# Altium schematic sheet Regulator.SchDoc
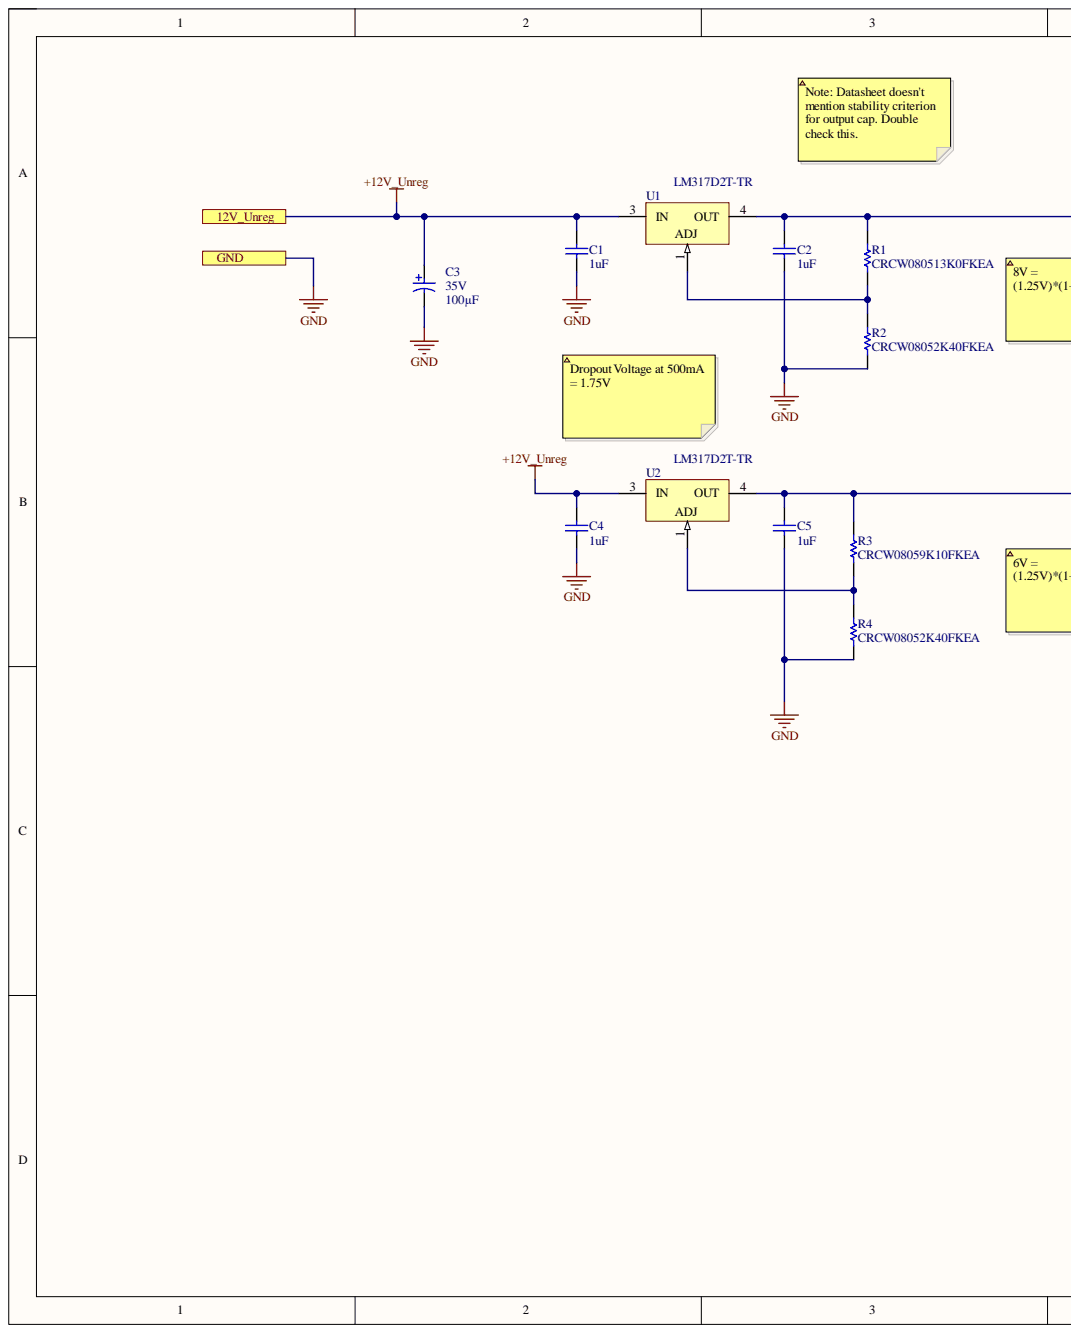
[diagram p0: Regulator.SchDoc, left]
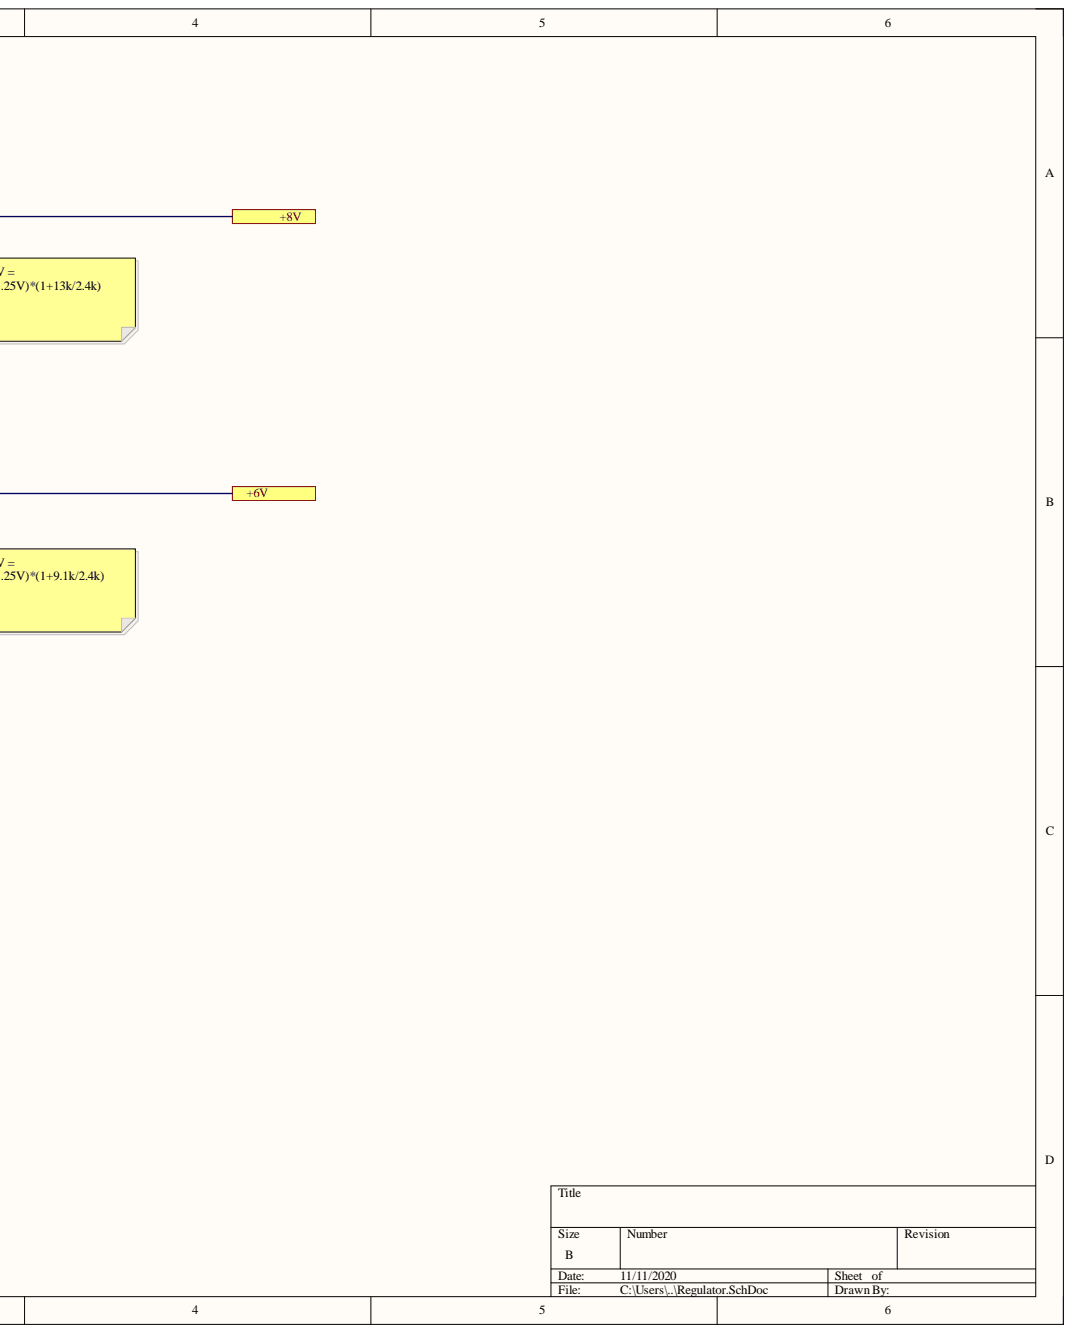
[diagram p1: Regulator.SchDoc, right]

## Parts
C1  1uF  [CAPC2013X100X50NL20T25]
C2  1uF  [CAPC2013X100X50NL20T25]
C3  Capacitance: 100µF  Voltage Rating: 35V  [PNSC-FC-V-G_V]  (hidden comment: EEEFC1V101P)
C4  1uF  [CAPC2013X100X50NL20T25]
C5  1uF  [CAPC2013X100X50NL20T25]
R1  CRCW080513K0FKEA  [RESC2013X50X30LL20T20]
R2  CRCW08052K40FKEA  [RESC2013X50X30NL20T20]
R3  CRCW08059K10FKEA  [RESC2013X50X30ML20T20]
R4  CRCW08052K40FKEA  [RESC2013X50X30NL20T20]
U1  LM317D2T-TR  [TO-263_N]
U2  LM317D2T-TR  [TO-263_N]

## Ports
12V_Unreg, +6V, +8V, GND

## Nets
+6V: C5.2 R3.2 U2.4 ; port +6V
+8V: C2.2 R1.2 U1.4 ; port +8V
+12V_Unreg: C1.2 C3.1 C4.2 U1.3 U2.3 ; port 12V_Unreg
GND: C1.1 C2.1 C3.2 C4.1 C5.1 R2.2 R4.2 ; port GND
NetR1_1: R1.1 R2.1 U1.1
NetR3_1: R3.1 R4.1 U2.1

## Library data of the parts
C1 C2 C4 C5 = CMP-2007-03716-1: Manufacturer: Kemet; Part Number: C0805X105K3RACTU; Component Kind: Standard; Library Name: Altium Content Vault
C3 = CMP-2000-06202-1: Aluminum Electrolytic Capacitor, 100 uF, +/- 20%, 35 V, 2-Pin SMD (Size Code G), -40 to 105 degC, RoHS, Tape and Reel; Manufacturer: Panasonic; AE Capacitor Case: RadialCan-SMD; External Length / Height: 10.2mm; External Width: 10.3mm; Impedance: 0.4ohm; Impedance Frequency: 100kHz; Impedance Temperature: 20°C; Lead Cross-section Width: 0.9mm; Life Time @ Temperature: 1000hours@105°C; Operating life Time: 1000h; Power Factor: 0.12; Power Factor Frequency: 120Hz; Product Range: PANASONIC-FCSeries; Ripple Current AC: 220mA; Ripple Current Temperature: 105°C; SVHC: ToBeAdvised; Capacitance Tolerance: ±20%; Capacitance Tolerance ±: ±20%; Capacitor Case Style: RadialCan-SMD; Component Kind: Standard; Datasheet URL: http://industrial.panasonic.com/cdbs/www-data/pdf/RDE0000/ABA0000C1172.pdf; Datasheet Version: 03/2016; Diameter: 10mm; Height: 10.2mm; Library Name: Altium Content Vault; Lifetime @ Temperature: 1000hours@105°C; Manufacturer URL: http://www.panasonic.com/; Mounting Technology: SurfaceMount; MSL: (NotAvailable); Operating Lifetime: 1000h; Operating Temperature Max: 105°C; Operating Temperature Min: -40°C; Operating Temperature Range: -40°Cto+105°C; Package / Case: G; Package Description: 2-Pin Electrolytic Aluminum Capacitor, Body 10.3 x 10.3 mm; Package Reference: FC-V-G; Package URL: http://industrial.panasonic.com/cdbs/www-data/pdf/RDE0000/DME0000COL48.pdf; Packaging: CutTape; Power Factor Temperature: 20°C; Ripple Current Frequency: 100kHz; Series: FC; Tape Width: 16mm; Tolerance +: 20%; Tolerance -: 20%; Voltage Rating V DC: 35V
R1 = CMP-2001-04945-1: Manufacturer: Vishay Dale; Component Kind: Standard; Library Name: Altium Content Vault; Part Number: CRCW080513K0FKEA
R2 R4 = CMP-2001-03768-1: Manufacturer: Vishay Dale; Component Kind: Standard; Library Name: Altium Content Vault; Part Number: CRCW08052K40FKEA
R3 = CMP-2001-04176-1: Manufacturer: Vishay Dale; Component Kind: Standard; Library Name: Altium Content Vault; Part Number: CRCW08059K10FKEA
U1 U2 = CMP-0244-00094-1: 1.5A, 1.2V to 37V Adjustable Voltage Regulator, 3-Pin D2PAK, Tape and Reel; Component Kind: Standard; ComponentLink1Description: Manufacturer URL; ComponentLink1URL: http://www.st.com; ComponentLink2Description: Datasheet; ComponentLink2URL: http://www.st.com/internet/com/TECHNICAL_RESOURCES/TECHNICAL_LITERATURE/DATASHEET/CD00000455.pdf; DatasheetVersion: 1/11/2011; Library Name: Altium Content Vault; PackageDescription: 3-Pin Transistor Outline Package 2.54 mm Pitch, 15.4 mm Lead Span (D2PAK); PackageReference: TO-263; PackageVersion: 2011
